# Altium schematic sheet [4]_Connectors_&_IO.SchDoc([4]_Connectors_&_IO)
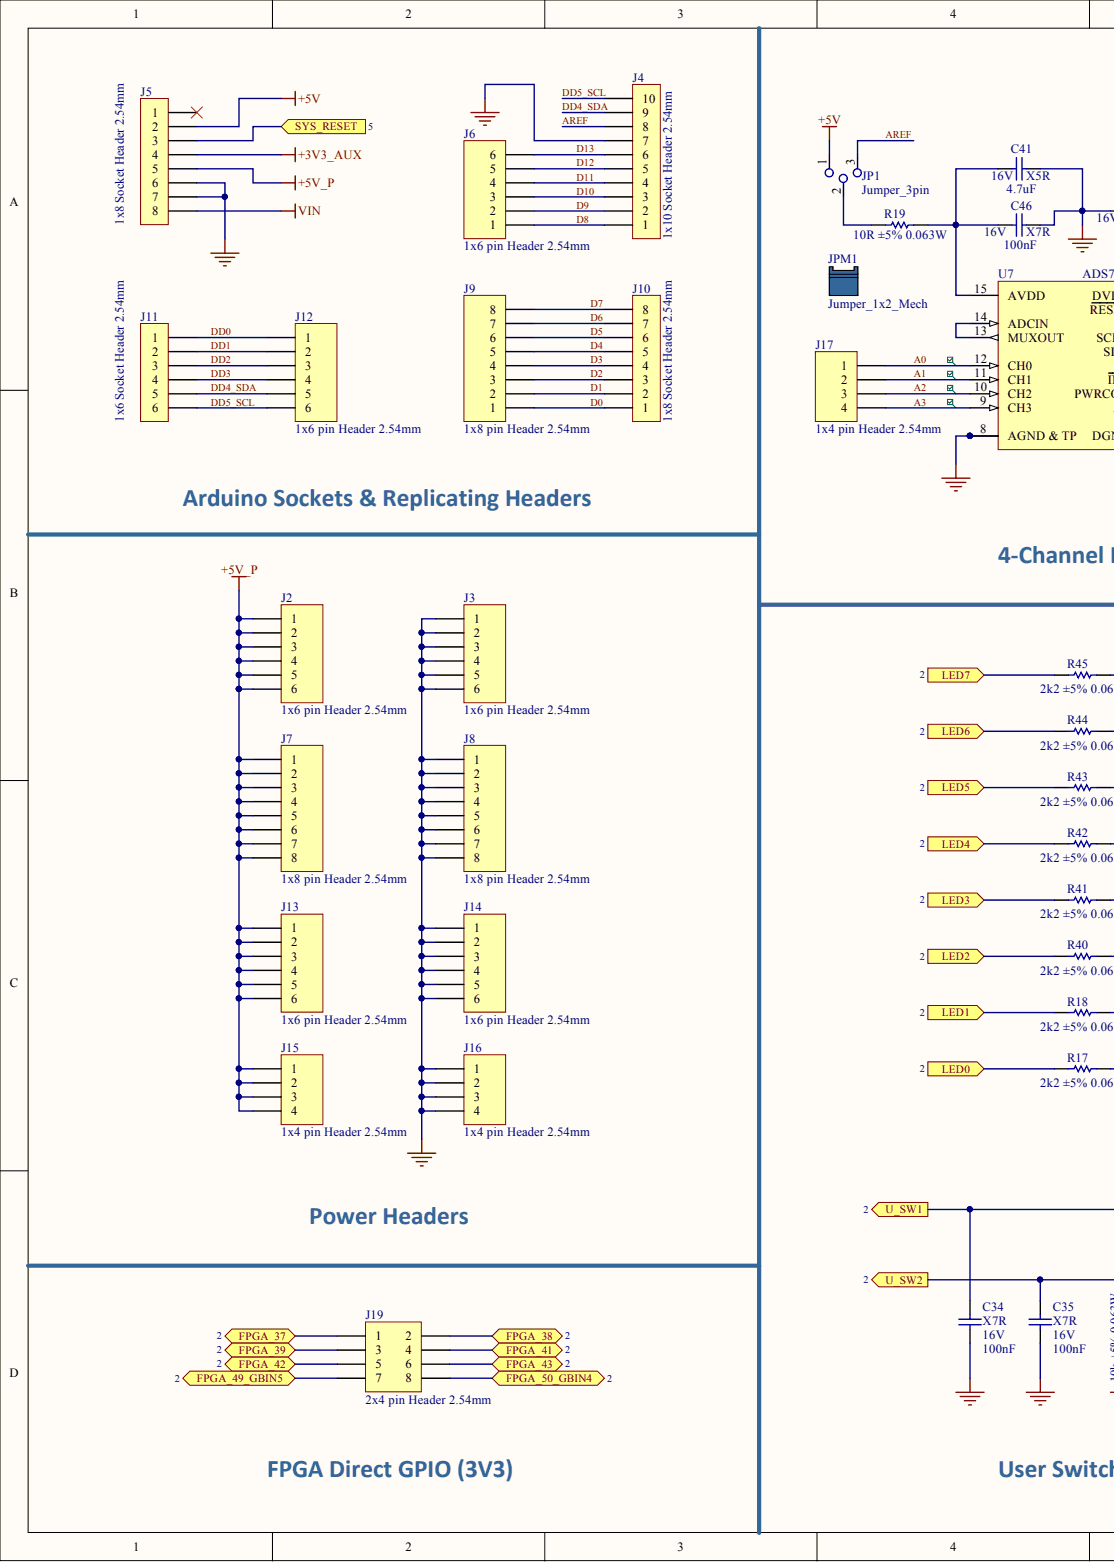
[diagram p0: [4]_Connectors_&_IO.SchDoc, left]
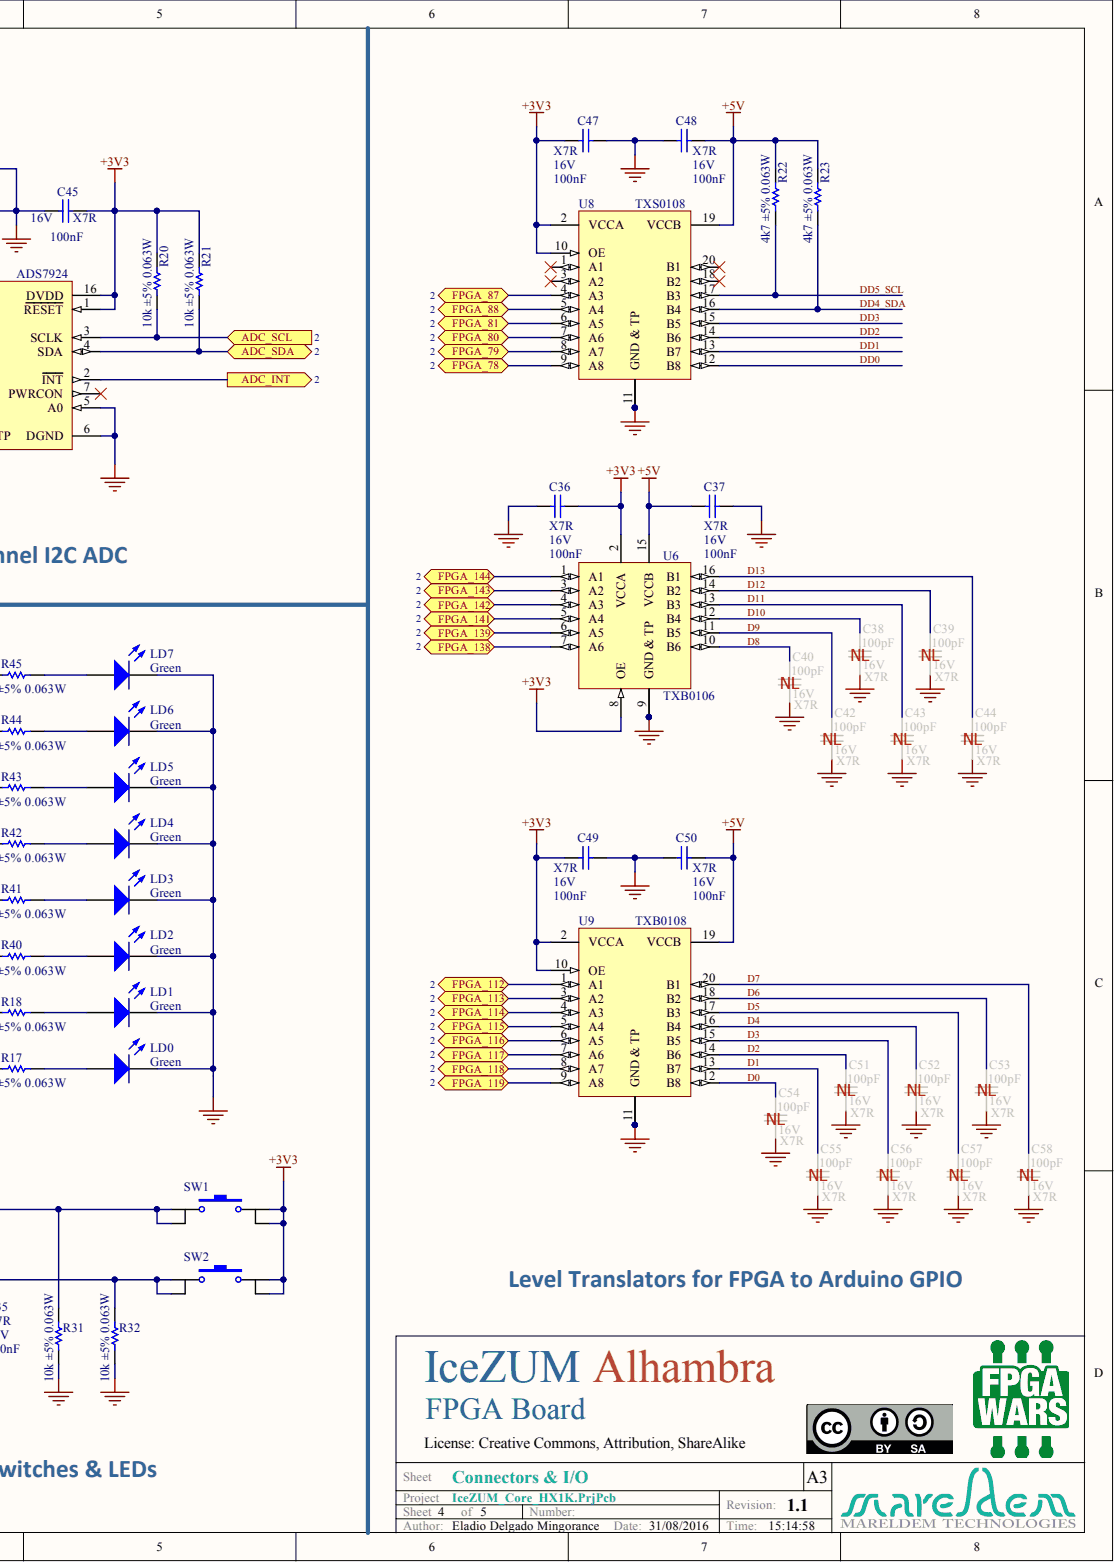
[diagram p1: [4]_Connectors_&_IO.SchDoc, right]

## Parts
C34  Capacitance: 100nF  Dielectric: X7R  Voltage: 16V  [C0402]  (hidden comment: Capacitor)
C35  Capacitance: 100nF  Dielectric: X7R  Voltage: 16V  [C0402]  (hidden comment: Capacitor)
C36  Dielectric: X7R  [C0402]  (hidden comment: Capacitor)
C37  Dielectric: X7R  [C0402]  (hidden comment: Capacitor)
C38  Capacitance: 100pF  Dielectric: X7R  Voltage: 16V  [C0402]  (hidden comment: Capacitor)
C39  Capacitance: 100pF  Dielectric: X7R  Voltage: 16V  [C0402]  (hidden comment: Capacitor)
C40  Capacitance: 100pF  Dielectric: X7R  Voltage: 16V  [C0402]  (hidden comment: Capacitor)
C41  Capacitance: 4.7uF  Dielectric: X5R  Voltage: 16V  [C0603]  (hidden comment: Capacitor)
C42  Capacitance: 100pF  Dielectric: X7R  Voltage: 16V  [C0402]  (hidden comment: Capacitor)
C43  Capacitance: 100pF  Dielectric: X7R  Voltage: 16V  [C0402]  (hidden comment: Capacitor)
C44  Capacitance: 100pF  Dielectric: X7R  Voltage: 16V  [C0402]  (hidden comment: Capacitor)
C45  Capacitance: 100nF  Dielectric: X7R  Voltage: 16V  [C0402]  (hidden comment: Capacitor)
C46  Capacitance: 100nF  Dielectric: X7R  Voltage: 16V  [C0402]  (hidden comment: Capacitor)
C47  Dielectric: X7R  Voltage: 16V  [C0402]  (hidden comment: Capacitor)
C48  Capacitance: 100nF  Dielectric: X7R  Voltage: 16V  [C0402]  (hidden comment: Capacitor)
C49  Dielectric: X7R  Voltage: 16V  [C0402]  (hidden comment: Capacitor)
C50  Capacitance: 100nF  Dielectric: X7R  Voltage: 16V  [C0402]  (hidden comment: Capacitor)
C51  Capacitance: 100pF  Dielectric: X7R  Voltage: 16V  [C0402]  (hidden comment: Capacitor)
C52  Capacitance: 100pF  Dielectric: X7R  Voltage: 16V  [C0402]  (hidden comment: Capacitor)
C53  Capacitance: 100pF  Dielectric: X7R  Voltage: 16V  [C0402]  (hidden comment: Capacitor)
C54  Capacitance: 100pF  Dielectric: X7R  Voltage: 16V  [C0402]  (hidden comment: Capacitor)
C55  Capacitance: 100pF  Dielectric: X7R  Voltage: 16V  [C0402]  (hidden comment: Capacitor)
C56  Capacitance: 100pF  Dielectric: X7R  Voltage: 16V  [C0402]  (hidden comment: Capacitor)
C57  Capacitance: 100pF  Dielectric: X7R  Voltage: 16V  [C0402]  (hidden comment: Capacitor)
C58  Capacitance: 100pF  Dielectric: X7R  Voltage: 16V  [C0402]  (hidden comment: Capacitor)
J2  1x6 pin Header 2.54mm  [1x6 Pin Header 2.54 Red]
J3  1x6 pin Header 2.54mm  value 6  Pitch: 2.54mm  [1x6 Pin Header 2.54]
J4  1x10 Socket Header 2.54mm  value 10  Pitch: 2.54mm  [1x10 Socket Header THT 2.54mm]
J5  1x8 Socket Header 2.54mm  value 8  Pitch: 2.54mm  [1x8 Socket Header THT 2.54mm]
J6  1x6 pin Header 2.54mm  Pitch: 2.54mm  [1x6 Pin Header 2.54 White]
J7  1x8 pin Header 2.54mm  [1x8 Pin Header 2.54 Red]
J8  1x8 pin Header 2.54mm  value 8  Pitch: 2.54mm  [1x8 Pin Header 2.54]
J9  1x8 pin Header 2.54mm  Pitch: 2.54mm  [1x8 Pin Header 2.54 White]
J10  1x8 Socket Header 2.54mm  value 8  Pitch: 2.54mm  [1x8 Socket Header THT 2.54mm]
J11  1x6 Socket Header 2.54mm  value 6  Pitch: 2.54mm  [1x6 Socket Header THT 2.54mm]
J12  1x6 pin Header 2.54mm  [1x6 Pin Header 2.54 White]
J13  1x6 pin Header 2.54mm  [1x6 Pin Header 2.54 Red]
J14  1x6 pin Header 2.54mm  value 6  Pitch: 2.54mm  [1x6 Pin Header 2.54]
J15  1x4 pin Header 2.54mm  [1x4 Pin Header 2.54 Red]
J16  1x4 pin Header 2.54mm  value 4  Pitch: 2.54mm  [1x4 Pin Header 2.54]
J17  1x4 pin Header 2.54mm  value 4  Pitch: 2.54mm  [1x4 Pin Header 2.54 Blue]
J19  2x4 pin Header 2.54mm  value 8  Pitch: 2.54mm  [2x4 Pin Header 2.54 Yellow]
JP1  Jumper_3pin  value 3  [1x3 Header 2.54 JP12]
JPM1  Jumper_1x2_Mech  (mechanical)
LD0  Green  [LED0603]
LD1  Green  [LED0603]
LD2  Green  [LED0603]
LD3  Green  [LED0603]
LD4  Green  [LED0603]
LD5  Green  [LED0603]
LD6  Green  [LED0603]
LD7  Green  [LED0603]
R17  value 2k2 ±5% 0.063W  Power: 0.063W  Resistance: 2k2  Tolerance: ±5%  [R0402]  (hidden comment: Resistor)
R18  value 2k2 ±5% 0.063W  Power: 0.063W  Resistance: 2k2  Tolerance: ±5%  [R0402]  (hidden comment: Resistor)
R19  value 10R ±5% 0.063W  Power: 0.063W  Resistance: 10R  Tolerance: ±5%  [R0402]  (hidden comment: Resistor)
R20  value 10k ±5% 0.063W  Power: 0.063W  Resistance: 10k  Tolerance: ±5%  [R0402]  (hidden comment: Resistor)
R21  value 10k ±5% 0.063W  Power: 0.063W  Resistance: 10k  Tolerance: ±5%  [R0402]  (hidden comment: Resistor)
R22  value 4k7 ±5% 0.063W  Power: 0.063W  Resistance: 4k7  Tolerance: ±5%  [R0402]  (hidden comment: Resistor)
R23  value 4k7 ±5% 0.063W  Power: 0.063W  Resistance: 4k7  Tolerance: ±5%  [R0402]  (hidden comment: Resistor)
R31  value 10k ±5% 0.063W  Power: 0.063W  Resistance: 10k  Tolerance: ±5%  [R0402]  (hidden comment: Resistor)
R32  value 10k ±5% 0.063W  Power: 0.063W  Resistance: 10k  Tolerance: ±5%  [R0402]  (hidden comment: Resistor)
R40  value 2k2 ±5% 0.063W  Power: 0.063W  Resistance: 2k2  Tolerance: ±5%  [R0402]  (hidden comment: Resistor)
R41  value 2k2 ±5% 0.063W  Power: 0.063W  Resistance: 2k2  Tolerance: ±5%  [R0402]  (hidden comment: Resistor)
R42  value 2k2 ±5% 0.063W  Power: 0.063W  Resistance: 2k2  Tolerance: ±5%  [R0402]  (hidden comment: Resistor)
R43  value 2k2 ±5% 0.063W  Power: 0.063W  Resistance: 2k2  Tolerance: ±5%  [R0402]  (hidden comment: Resistor)
R44  value 2k2 ±5% 0.063W  Power: 0.063W  Resistance: 2k2  Tolerance: ±5%  [R0402]  (hidden comment: Resistor)
R45  value 2k2 ±5% 0.063W  Power: 0.063W  Resistance: 2k2  Tolerance: ±5%  [R0402]  (hidden comment: Resistor)
SW1  Switch_4P_SP  [WE_434331013822]  (value not drawn)
SW2  Switch_4P_SP  [WE_434331013822]  (value not drawn)
U6  TXB0106  [QFN16]
U7  ADS7924  [QFN16]
U8  TXS0108  [VQFN20 4.5x3.5mm]
U9  TXB0108  [VQFN20 4.5x3.5mm]

## Ports
ADC_INT, ADC_SCL, ADC_SDA, FPGA_37, FPGA_38, FPGA_39, FPGA_41, FPGA_42, FPGA_43, FPGA_49_GBIN5, FPGA_50_GBIN4, FPGA_78, FPGA_79, FPGA_80, FPGA_81, FPGA_87, FPGA_88, FPGA_112, FPGA_113, FPGA_114, FPGA_115, FPGA_116, FPGA_117, FPGA_118, FPGA_119, FPGA_138, FPGA_139, FPGA_141, FPGA_142, FPGA_143, FPGA_144, LED0, LED1, LED2, LED3, LED4, LED5, LED6, LED7, SYS_RESET, U_SW1, U_SW2

## Nets
+3V3: C36.1 C45.2 C47.2 C49.2 R20.2 R21.2 SW1.3 SW1.4 SW2.3 SW2.4 U6.2(VCCA) U6.8(OE) U7.1(RESET) U7.16(DVDD) U8.2(VCCA) U8.10(OE) U9.2(VCCA) U9.10(OE)
+3V3_AUX: J5.4
+5V: C37.2 C48.1 C50.1 J5.2 JP1.1 R22.2 R23.2 U6.15(VCCB) U8.19(VCCB) U9.19(VCCB)
+5V_P: J2.1 J2.2 J2.3 J2.4 J2.5 J2.6 J5.5 J7.1 J7.2 J7.3 J7.4 J7.5 J7.6 J7.7 J7.8 J13.1 J13.2 J13.3 J13.4 J13.5 J13.6 J15.1 J15.2 J15.3 J15.4
GND: C34.1 C35.1 C36.2 C37.1 C38.1 C39.1 C40.1 C41.1 C42.1 C43.1 C44.1 C45.1 C46.2 C47.1 C48.2 C49.1 C50.2 C51.1 C52.1 C53.1 C54.1 C55.1 C56.1 C57.1 C58.1 J3.1 J3.2 J3.3 J3.4 J3.5 J3.6 J4.7 J5.6 J5.7 J8.1 J8.2 J8.3 J8.4 J8.5 J8.6 J8.7 J8.8 J14.1 J14.2 J14.3 J14.4 J14.5 J14.6 J16.1 J16.2 J16.3 J16.4 LD0.K LD1.K LD2.K LD3.K LD4.K LD5.K LD6.K LD7.K R31.1 R32.1 U6.0(GND_&_TP) U6.9 U7.0 U7.5(A0) U7.6(DGND) U7.8 U8.0(GND_&_TP) U8.11 U9.0(GND_&_TP) U9.11
VIN: J5.8
A0: J17.1 U7.12(CH0)
A1: J17.2 U7.11(CH1)
A2: J17.3 U7.10(CH2)
A3: J17.4 U7.9(CH3)
ADC_INT: U7.2(INT) ; port ADC_INT
ADC_SCL: R20.1 U7.3(SCLK) ; port ADC_SCL
ADC_SDA: R21.1 U7.4(SDA) ; port ADC_SDA
AREF: J4.8 JP1.3
D0: C54.2 J9.1 J10.1 U9.12(B8)
D1: C55.2 J9.2 J10.2 U9.13(B7)
D2: C51.2 J9.3 J10.3 U9.14(B6)
D3: C56.2 J9.4 J10.4 U9.15(B5)
D4: C52.2 J9.5 J10.5 U9.16(B4)
D5: C57.2 J9.6 J10.6 U9.17(B3)
D6: C53.2 J9.7 J10.7 U9.18(B2)
D7: C58.2 J9.8 J10.8 U9.20(B1)
D8: C40.2 J4.1 J6.1 U6.10(B6)
D9: C42.2 J4.2 J6.2 U6.11(B5)
D10: C38.2 J4.3 J6.3 U6.12(B4)
D11: C43.2 J4.4 J6.4 U6.13(B3)
D12: C39.2 J4.5 J6.5 U6.14(B2)
D13: C44.2 J4.6 J6.6 U6.16(B1)
DD0: J11.1 J12.1 U8.12(B8)
DD1: J11.2 J12.2 U8.13(B7)
DD2: J11.3 J12.3 U8.14(B6)
DD3: J11.4 J12.4 U8.15(B5)
DD4_SDA: J4.9 J11.5 J12.5 R23.1 U8.16(B4)
DD5_SCL: J4.10 J11.6 J12.6 R22.1 U8.17(B3)
FPGA_37: J19.1 ; port FPGA_37
FPGA_38: J19.2 ; port FPGA_38
FPGA_39: J19.3 ; port FPGA_39
FPGA_41: J19.4 ; port FPGA_41
FPGA_42: J19.5 ; port FPGA_42
FPGA_43: J19.6 ; port FPGA_43
FPGA_49_GBIN5: J19.7 ; port FPGA_49_GBIN5
FPGA_50_GBIN4: J19.8 ; port FPGA_50_GBIN4
FPGA_78: U8.9(A8) ; port FPGA_78
FPGA_79: U8.8(A7) ; port FPGA_79
FPGA_80: U8.7(A6) ; port FPGA_80
FPGA_81: U8.6(A5) ; port FPGA_81
FPGA_87: U8.4(A3) ; port FPGA_87
FPGA_88: U8.5(A4) ; port FPGA_88
FPGA_112: U9.1(A1) ; port FPGA_112
FPGA_113: U9.3(A2) ; port FPGA_113
FPGA_114: U9.4(A3) ; port FPGA_114
FPGA_115: U9.5(A4) ; port FPGA_115
FPGA_116: U9.6(A5) ; port FPGA_116
FPGA_117: U9.7(A6) ; port FPGA_117
FPGA_118: U9.8(A7) ; port FPGA_118
FPGA_119: U9.9(A8) ; port FPGA_119
FPGA_138: U6.7(A6) ; port FPGA_138
FPGA_139: U6.6(A5) ; port FPGA_139
FPGA_141: U6.5(A4) ; port FPGA_141
FPGA_142: U6.4(A3) ; port FPGA_142
FPGA_143: U6.3(A2) ; port FPGA_143
FPGA_144: U6.1(A1) ; port FPGA_144
LED0: R17.1 ; port LED0
LED1: R18.1 ; port LED1
LED2: R40.1 ; port LED2
LED3: R41.1 ; port LED3
LED4: R42.1 ; port LED4
LED5: R43.1 ; port LED5
LED6: R44.1 ; port LED6
LED7: R45.1 ; port LED7
SYS_RESET: J5.3 ; port SYS_RESET
U_SW1: C34.2 R31.2 SW1.1 SW1.2 ; port U_SW1
U_SW2: C35.2 R32.2 SW2.1 SW2.2 ; port U_SW2
NetC41_2: C41.2 C46.1 R19.2 U7.15(AVDD)
NetJP1_2: JP1.2 R19.1
NetLD0_A: LD0.A R17.2
NetLD1_A: LD1.A R18.2
NetLD2_A: LD2.A R40.2
NetLD3_A: LD3.A R41.2
NetLD4_A: LD4.A R42.2
NetLD5_A: LD5.A R43.2
NetLD6_A: LD6.A R44.2
NetLD7_A: LD7.A R45.2
NetU7_13: U7.13(MUXOUT) U7.14(ADCIN)

Not connected: J5 1; U7 7(PWRCON); U8 1(A1) 3(A2) 18(B2) 20(B1)

## Library data of the parts
C34 C35 C45 C46 C48 C50 = C0402_100nF_16V_±10%: Capacitor SMD; Manufacturer: Kemet; Database Table Name: C0402_16V$; OrderingCode: C0402C104K4RAC; Temp_ Max: 125; Temp_ Min: -55; Tolerance: ±10%; Value: 100nF ±10% 16V; Component Kind: Standard; Library Name: Pasives_Capacitor_SMD.DbLib; Part Number: C0402_100nF_16V_±10%; Price (€): 0,05
C36 C37 = C0402_100nF_16V_±10%: Capacitor SMD; Manufacturer: Kemet; Capacitance: 100nF; Database Table Name: C0402_16V$; OrderingCode: C0402C104K4RAC; Temp_ Max: 125; Temp_ Min: -55; Tolerance: ±10%; Value: 100nF ±10% 16V; Voltage: 16V; Component Kind: Standard; Library Name: Pasives_Capacitor_SMD.DbLib; Part Number: C0402_100nF_16V_±10%; Price (€): 0,05
C38-C40 C42-C44 C51-C58 = C0402_100pF_16V_±10%: Capacitor SMD; Manufacturer: Kemet; Database Table Name: C0402_16V$; OrderingCode: C0402C101K4RAC; Temp_ Max: 125; Temp_ Min: -55; Tolerance: ±10%; Value: 100pF ±10% 16V; Component Kind: Standard; Library Name: Pasives_Capacitor_SMD.DbLib; Part Number: C0402_100pF_16V_±10%; Price (€): 0,036
C41 = C0603_4.7uF_16V_±10%: Capacitor SMD; Manufacturer: TDK; Database Table Name: C0603_16V$; OrderingCode: C1608X5R1C475K080AC; Temp_ Max: 85; Temp_ Min: -55; Tolerance: ±10%; Value: 4.7uF ±10% 16V; Component Kind: Standard; Library Name: Pasives_Capacitor_SMD.DbLib; Part Number: C0603_4.7uF_16V_±10%; Price (€): 0,068
C47 C49 = C0402_100nF_16V_±10%: Capacitor SMD; Manufacturer: Kemet; Capacitance: 100nF; Database Table Name: C0402_16V$; OrderingCode: C0402C104K4RAC; Temp_ Max: 125; Temp_ Min: -55; Tolerance: ±10%; Value: 100nF ±10% 16V; Component Kind: Standard; Library Name: Pasives_Capacitor_SMD.DbLib; Part Number: C0402_100nF_16V_±10%; Price (€): 0,05
J2 J13 = CON_PH_THT2.54_6 Red: Manufacturer: Samtec; Database Table Name: Con_Pin_Header_THT_2#54$; OrderingCode: TSW-106-07-T-S-RED; Temp_ Max: 125; Temp_ Min: -40; Component Kind: Standard; Description: 1x6 pin Header 2.54mm; Library Name: Connector_PinHeader_2.54.DbLib; Part Number: CON_PH_THT2.54_6 Red; Pitch: 2.54mm; Price (€): 0,26; Supplier: Samtec
J3 J14 = CON_PH_THT2.54_6: Manufacturer: Samtec; Database Table Name: Con_Pin_Header_THT_2#54$; OrderingCode: TSW-106-07-T-S; OrderingCode 2: 613 006 111 21; Temp_ Max: 125; Temp_ Min: -40; Component Kind: Standard; Description: 1x6 pin Header 2.54mm; Library Name: Connector_PinHeader_2.54.DbLib; Manufacturer 2: Würth Elektronik; Part Number: CON_PH_THT2.54_6; Price (€): 0,26; Supplier: Samtec
J4 = CON_SH_THT2.54_10: Connector, 10 pin Socket Header 2.54mm; Manufacturer: Samtec; Database Table Name: Con_Socket_Header_THT_2#54$; OrderingCode: SSW-110-01-T-S; OrderingCode 2: 613 010 118 21; Temp_ Max: 125; Temp_ Min: -40; Component Kind: Standard; Library Name: Connector_SocketHeader_2.54.DbLib; Manufacturer 2: Würth Elektronik; Part Number: CON_SH_THT2.54_10; Price (€): 0,578; Supplier: Samtec
J5 J10 = CON_SH_THT2.54_8: 8 pin Socket Header 2.54mm; Manufacturer: Samtec; Database Table Name: Con_Socket_Header_THT_2#54$; OrderingCode: SSW-108-01-T-S; OrderingCode 2: 613 008 118 21; Temp_ Max: 125; Temp_ Min: -40; Component Kind: Standard; Library Name: Connector_SocketHeader_2.54.DbLib; Manufacturer 2: Würth Elektronik; Part Number: CON_SH_THT2.54_8; Price (€): 0,438; Supplier: Samtec
J6 = CON_PH_THT2.54_6 Natural: Manufacturer: Samtec; Database Table Name: Con_Pin_Header_THT_2#54$; OrderingCode: TSW-106-07-T-S-NATURAL; Temp_ Max: 125; Temp_ Min: -40; Component Kind: Standard; Description: 1x6 pin Header 2.54mm; Library Name: Connector_PinHeader_2.54.DbLib; Part Number: CON_PH_THT2.54_6 Natural; Price (€): 0,26; Supplier: Samtec
J7 = CON_PH_THT2.54_8 Red: Manufacturer: Samtec; Database Table Name: Con_Pin_Header_THT_2#54$; OrderingCode: TSW-108-07-T-S-RED; Temp_ Max: 125; Temp_ Min: -40; Component Kind: Standard; Description: 1x8 pin Header 2.54mm; Library Name: Connector_PinHeader_2.54.DbLib; Part Number: CON_PH_THT2.54_8 Red; Pitch: 2.54mm; Price (€): 0,35; Supplier: Samtec
J8 = CON_PH_THT2.54_8: Manufacturer: Samtec; Database Table Name: Con_Pin_Header_THT_2#54$; OrderingCode: TSW-108-07-T-S; OrderingCode 2: 613 008 111 21; Temp_ Max: 125; Temp_ Min: -40; Component Kind: Standard; Description: 1x8 pin Header 2.54mm; Library Name: Connector_PinHeader_2.54.DbLib; Manufacturer 2: Würth Elektronik; Part Number: CON_PH_THT2.54_8; Price (€): 0,35; Supplier: Samtec
J9 = CON_PH_THT2.54_8 Natural: Manufacturer: Samtec; Database Table Name: Con_Pin_Header_THT_2#54$; OrderingCode: TSW-108-07-T-S-NATURAL; Temp_ Max: 125; Temp_ Min: -40; Component Kind: Standard; Description: 1x8 pin Header 2.54mm; Library Name: Connector_PinHeader_2.54.DbLib; Part Number: CON_PH_THT2.54_8 Natural; Price (€): 0,35; Supplier: Samtec
J11 = CON_SH_THT2.54_6: 6 pin Socket Header 2.54mm; Manufacturer: Samtec; Database Table Name: Con_Socket_Header_THT_2#54$; OrderingCode: SSW-106-01-T-S; OrderingCode 2: 613 006 118 21; Temp_ Max: 125; Temp_ Min: -40; Component Kind: Standard; Library Name: Connector_SocketHeader_2.54.DbLib; Manufacturer 2: Würth Elektronik; Part Number: CON_SH_THT2.54_6; Price (€): 0,381; Supplier: Samtec
J12 = CON_PH_THT2.54_6 Natural: Connector, 1x6 pin Header 2.54mm; Manufacturer: Samtec; Database Table Name: Con_Pin_Header_THT_2#54$; OrderingCode: TSW-106-07-T-S-NATURAL; Temp_ Max: 105; Temp_ Min: -55; Component Kind: Standard; Library Name: Connector_PinHeader_2.54.DbLib; Part Number: CON_PH_THT2.54_6 Natural; Pitch: 2.54mm; Price (€): 0,26; Supplier: Samtec
J15 = CON_PH_THT2.54_4 Red: Connector, 1x4 pin Header 2.54mm; Manufacturer: Samtec; Database Table Name: Con_Pin_Header_THT_2#54$; OrderingCode: TSW-104-07-T-S-RED; Temp_ Max: 105; Temp_ Min: -55; Component Kind: Standard; Library Name: Connector_PinHeader_2.54.DbLib; Part Number: CON_PH_THT2.54_4 Red; Pitch: 2.54mm; Price (€): 0,23; Supplier: Samtec
J16 = CON_PH_THT2.54_4: Connector, 1x4 pin Header 2.54mm; Manufacturer: Samtec; Database Table Name: Con_Pin_Header_THT_2#54$; OrderingCode: TSW-104-07-T-S; OrderingCode 2: 613 004 111 21; Temp_ Max: 105; Temp_ Min: -55; Component Kind: Standard; Library Name: Connector_PinHeader_2.54.DbLib; Manufacturer 2: Würth Elektronik; Part Number: CON_PH_THT2.54_4; Price (€): 0,211; Supplier: Samtec
J17 = CON_PH_THT2.54_4: Connector, 1x4 pin Header 2.54mm; Manufacturer: Samtec; Part Number: CON_PH_THT2.54_4 Blue; Database Table Name: Con_Pin_Header_THT_2#54$; OrderingCode: TSW-104-07-T-S-BLUE; OrderingCode 2: 613 004 111 21; Temp_ Max: 105; Temp_ Min: -55; Component Kind: Standard; Library Name: Connector_PinHeader_2.54.DbLib; Manufacturer 2: Würth Elektronik; Price (€): 0,211; Supplier: Samtec
J19 = CON_DPH_THT2.54_8: Connector, 8 pin Dual Header 2.54mm; Manufacturer: Samtec; Database Table Name: Con_Dual_Pin_Header_THT_2#54$; OrderingCode: TSW-104-07-T-D; OrderingCode 2: 613 008 211 21; Temp_ Max: 125; Temp_ Min: -40; Component Kind: Standard; Library Name: Connector_PinHeader_2.54.DbLib; Manufacturer 2: Würth Elektronik; Part Number: CON_DPH_THT2.54_8; Price (€): 0,305; Supplier: Samtec
JP1 = Jumper_3pin_THT_JP12: Connector, Jumper, 3 pin Male Header 2.54mm; Manufacturer: Würth; Database Table Name: Jumper$; OrderingCode: 613 003 111 21 / 609 002 136 21; Temp_ Max: 125; Temp_ Min: -40; Component Kind: Standard; Library Name: Connector_Jumper.DbLib; Part Number: Jumper_3pin_THT_JP12; Price (€): 0,15; Supplier: Würth
JPM1 = Jumper_2pin_Mech: Connector, Jumper, 1x2 Jumper 2.54mm; Manufacturer: Würth; Database Table Name: Jumper$; OrderingCode: 609 002 136 21; Temp_ Max: 125; Temp_ Min: -40; Value: Blue 1x2; Library Name: Connectors_Jumpers.SVNDbLib; Part Number: Jumper_2pin_Mech; Price (€): 0,05; Supplier: Würth
LD0-LD7 = LED Green 0603: LED; Manufacturer: OSRAM Opto; Database Table Name: LED_SMD$; OrderingCode: LG Q396-PS-35; Temp_ Max: 85; Temp_ Min: -30; Component Kind: Standard; Library Name: Optoelectronics.DbLib; Part Number: LED Green 0603; Price (€): 0,058; Value: Green
R17 R18 R40-R45 = R0402_2k2_0.063W_±5%: Resistor, SMD; Manufacturer: Vishay; Database Table Name: R0402_5%$; OrderingCode: CRCW04022K20JN; Temp_ Max: 155; Temp_ Min: -55; Component Kind: Standard; Library Name: Pasives_Resistor_SMD.DbLib; PackageDescription: SMD; Part Number: R0402_2k2_0.063W_±5%; Price (€): 0,003
R19 = R0402_10R_0.063W_±5%: Resistor, SMD; Manufacturer: Vishay; Database Table Name: R0402_5%$; OrderingCode: CRCW040210R0JN; Temp_ Max: 155; Temp_ Min: -55; Component Kind: Standard; Library Name: Pasives_Resistor_SMD.DbLib; PackageDescription: SMD; Part Number: R0402_10R_0.063W_±5%; Price (€): 0,003
R20 R21 R31 R32 = R0402_10k_0.063W_±5%: Resistor, SMD; Manufacturer: Vishay; Database Table Name: R0402_5%$; OrderingCode: CRCW040210K0JN; Temp_ Max: 155; Temp_ Min: -55; Component Kind: Standard; Library Name: Pasives_Resistor_SMD.DbLib; PackageDescription: SMD; Part Number: R0402_10k_0.063W_±5%; Price (€): 0,003
R22 R23 = R0402_4k7_0.063W_±5%: Resistor, SMD; Manufacturer: Vishay; Database Table Name: R0402_5%$; OrderingCode: CRCW04024K70JN; Temp_ Max: 155; Temp_ Min: -55; Component Kind: Standard; Library Name: Pasives_Resistor_SMD.DbLib; PackageDescription: SMD; Part Number: R0402_4k7_0.063W_±5%; Price (€): 0,003
SW1 SW2 = SW 3.5x2.9 mm SMD side push: Micro miniature pushbutton surface Switch; Manufacturer: Würth; Database Table Name: Switch$; OrderingCode: 434331013822; Temp_ Max: 85; Temp_ Min: -40; Component Kind: Standard; Library Name: Electromechanical.DbLib; Part Number: SW 3.5x2.9 mm SMD side push; Price (€): 0,2; Supplier: Würth
U6 = TXB0106: Voltage Translator, Hex; Manufacturer: Texas Instruments; Database Table Name: Voltage_translator$; OrderingCode: TXB0106RGYR; Temp_ Max: 85; Temp_ Min: -40; Component Kind: Standard; Library Name: Voltage_translator.DbLib; Part Number: TXB0106; Price (€): 0,7; Supplier: Silica
U7 = ADS7924: ADC Converter, 12-Bit, 4-Ch, I2C; Manufacturer: Texas Instruments; Database Table Name: ADC$; OrderingCode: ADS7924IRTER; Temp_ Max: 85; Temp_ Min: -40; Component Kind: Standard; Part Number: ADS7924; Price (€): 1,35; Supplier: Silica; Library Name: Data_Converters.SVNDbLib
U8 = TXS0108: Voltage Level Translator, 8-Bit Bidir., with TP; Manufacturer: Texas Instruments; Database Table Name: Voltage_translator$; OrderingCode: TXS0108ERGYR; Temp_ Max: 85; Temp_ Min: -40; Component Kind: Standard; Library Name: Voltage_translator.DbLib; Part Number: TXS0108; Price (€): 0,832; Supplier: Silica
U9 = TXB0108: Voltage Level Translator, 8-Bit Bidir., with TP; Manufacturer: Texas Instruments; Database Table Name: Voltage_translator$; OrderingCode: TXB0108RGYR; Temp_ Max: 85; Temp_ Min: -40; Component Kind: Standard; Library Name: Voltage_translator.DbLib; Part Number: TXB0108; Price (€): 0,832; Supplier: Silica
